# Altium schematic sheet Ttester.SchDoc
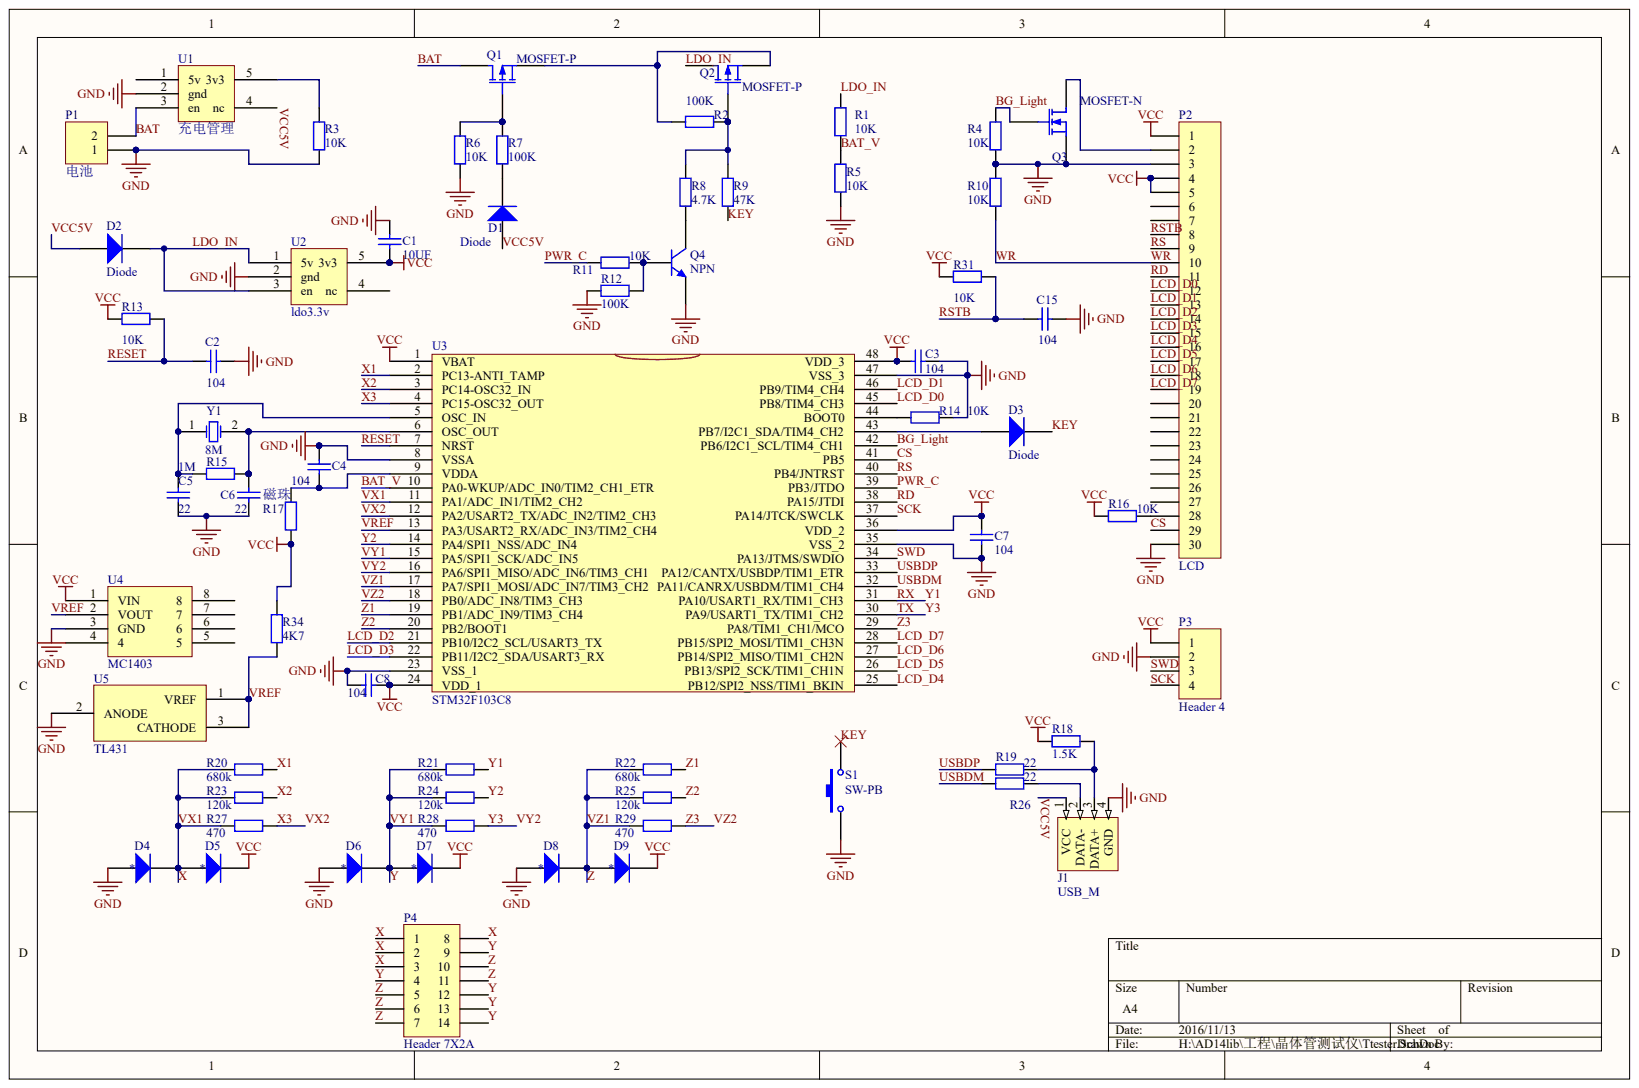
[diagram p0: Ttester.SchDoc]

## Parts
C1  value 10UF  (hidden comment: Cap)
C2  value 104  (hidden comment: Cap)
C3  value 104  (hidden comment: Cap)
C4  value 104  (hidden comment: Cap)
C5  value 22  (hidden comment: Cap)
C6  value 22  (hidden comment: Cap)
C7  value 104  (hidden comment: Cap)
C8  value 104  (hidden comment: Cap)
C15  value 104  (hidden comment: Cap)
D1  Diode
D2  Diode
D3  Diode
D4  *
D5  *
D6  *
D7  *
D8  *
D9  *
J1  USB_M
P1  电池
P2  LCD
P3  Header 4
P4  Header 7X2A
Q1  MOSFET-P
Q2  MOSFET-P
Q3  MOSFET-N
Q4  NPN
R1  value 10K  (hidden comment: Res2)
R2  value 100K  (hidden comment: Res2)
R3  value 10K  (hidden comment: Res2)
R4  value 10K  (hidden comment: Res2)
R5  value 10K  (hidden comment: Res2)
R6  value 10K  (hidden comment: Res2)
R7  value 100K  (hidden comment: Res2)
R8  value 4.7K  (hidden comment: Res2)
R9  value 47K  (hidden comment: Res2)
R10  value 10K  (hidden comment: Res2)
R11  value 10K  (hidden comment: Res2)
R12  value 100K  (hidden comment: Res2)
R13  value 10K  (hidden comment: Res2)
R14  value 10K  (hidden comment: Res2)
R15  value 1M  (hidden comment: Res2)
R16  value 10K  (hidden comment: Res2)
R17  value 磁珠  (hidden comment: 0)
R18  value 1.5K  (hidden comment: Res2)
R19  value 22  (hidden comment: Res2)
R20  value 680k  (hidden comment: Res2)
R21  value 680k  (hidden comment: Res2)
R22  value 680k  (hidden comment: Res2)
R23  value 120k  (hidden comment: Res2)
R24  value 120k  (hidden comment: Res2)
R25  value 120k  (hidden comment: Res2)
R26  value 22  (hidden comment: Res2)
R27  value 470  (hidden comment: Res2)
R28  value 470  (hidden comment: Res2)
R29  value 470  (hidden comment: Res2)
R31  value 10K  (hidden comment: Res2)
R34  value 4K7  (hidden comment: Res2)
S1  SW-PB
U1  充电管理
U2  ldo3.3v
U3  STM32F103C8
U4  MC1403
U5  TL431
Y1  8M

## Nets
GND: C1.2 C2.2 C3.2 C4.2 C5.1 C6.1 C7.1 C8.1 C15.2 D4.1 D6.1 D8.1 J1.4(GND) P1.1 P2.3 P2.30 P3.2 Q3.3 Q4.3 R3.1 R4.2 R5.2 R6.2 R10.1 R12.1 R14.1 S1.1 U1.2(gnd) U2.2(gnd) U3.8(VSSA) U3.23(VSS_1) U3.35(VSS_2) U3.47(VSS_3) U4.3(GND) U5.2
VCC: C1.1 C3.1 C7.2 C8.2 D5.2 D7.2 D9.2 P2.1 P2.4 P2.5 P3.1 R13.1 R16.2 R17.1 R18.2 R31.1 R34.2 U2.5(3v3) U3.1(VBAT) U3.24(VDD_1) U3.36(VDD_2) U3.48(VDD_3) U4.1(VIN)
BAT: P1.2 Q1.2 U1.3(en)
BAT_V: R1.2 R5.1 U3.10(PA0-WKUP/ADC_IN0/TIM2_CH1_ETR)
BG_LIGHT: Q3.1 R4.1 U3.42(PB6/I2C1_SCL/TIM4_CH1)
CS: P2.29 U3.41(PB5)
KEY: D3.2 R9.2 S1.2
LCD_D0: P2.12 U3.45(PB8/TIM4_CH3)
LCD_D1: P2.13 U3.46(PB9/TIM4_CH4)
LCD_D2: P2.14 U3.21(PB10/I2C2_SCL/USART3_TX)
LCD_D3: P2.15 U3.22(PB11/I2C2_SDA/USART3_RX)
LCD_D4: P2.16 U3.25(PB12/SPI2_NSS/TIM1_BKIN)
LCD_D5: P2.17 U3.26(PB13/SPI2_SCK/TIM1_CH1N)
LCD_D6: P2.18 U3.27(PB14/SPI2_MISO/TIM1_CH2N)
LCD_D7: P2.19 U3.28(PB15/SPI2_MOSI/TIM1_CH3N)
LDO_IN: D2.2 Q2.2 R1.1 U2.1(5v) U2.3(en)
PWR_C: R11.1 U3.39(PB3/JTDO)
RD: P2.11 U3.38(PA15/JTDI)
RESET: C2.1 R13.2 U3.7(NRST)
RS: P2.9 U3.40(PB4/JNTRST)
RSTB: C15.1 P2.8 R31.2
RX: R21.2 U3.31(PA10/USART1_RX/TIM1_CH3)
SCK: P3.4 U3.37(PA14/JTCK/SWCLK)
SWD: P3.3 U3.34(PA13/JTMS/SWDIO)
TX: R28.2 U3.16(PA6/SPI1_MISO/ADC_IN6/TIM3_CH1) U3.30(PA9/USART1_TX/TIM1_CH2)
USBDM: R26.1 U3.32(PA11/CANRX/USBDM/TIM1_CH4)
USBDP: R19.1 U3.33(PA12/CANTX/USBDP/TIM1_ETR)
VCC5V: D1.1 D2.1 J1.1(VCC) U1.4(nc)
VREF: R34.1 U3.13(PA3/USART2_RX/ADC_IN3/TIM2_CH4) U4.2(VOUT) U5.1 U5.3
VX1: D4.2 D5.1 P4.1 P4.2 P4.3 P4.8 R20.1 R23.1 R27.1 U3.11(PA1/ADC_IN1/TIM2_CH2)
VX2: R27.2 U3.4(PC15-OSC32_OUT) U3.12(PA2/USART2_TX/ADC_IN2/TIM2_CH3)
VY1: D6.2 D7.1 P4.4 P4.9 P4.12 P4.13 P4.14 R21.1 R24.1 R28.1 U3.15(PA5/SPI1_SCK/ADC_IN5)
VZ1: D8.2 D9.1 P4.5 P4.6 P4.7 P4.10 P4.11 R22.1 R25.1 R29.1 U3.17(PA7/SPI1_MOSI/ADC_IN7/TIM3_CH2)
VZ2: R29.2 U3.18(PB0/ADC_IN8/TIM3_CH3) U3.29(PA8/TIM1_CH1/MCO)
WR: P2.10 R10.2
X1: R20.2 U3.2(PC13-ANTI_TAMP)
X2: R23.2 U3.3(PC14-OSC32_IN)
Y2: R24.2 U3.14(PA4/SPI1_NSS/ADC_IN4)
Z1: R22.2 U3.19(PB1/ADC_IN9/TIM3_CH4)
Z2: R25.2 U3.20(PB2/BOOT1)
NetC4_1: C4.1 R17.2 U3.9(VDDA)
NetC5_2: C5.2 R15.1 U3.5(OSC_IN) Y1.1
NetC6_2: C6.2 R15.2 U3.6(OSC_OUT) Y1.2
NetD1_2: D1.2 R7.2
NetD3_1: D3.1 U3.43(PB7/I2C1_SDA/TIM4_CH2)
NetJ1_2: J1.2(DATA-) R26.2
NetJ1_3: J1.3(DATA+) R18.1 R19.2
NetP2_2: P2.2 Q3.2
NetP2_28: P2.28 R16.1
NetQ1_1: Q1.1 R6.1 R7.1
NetQ1_3: Q1.3 Q2.3 R2.2
NetQ2_1: Q2.1 R2.1 R8.1 R9.1
NetQ4_1: Q4.1 R8.2
NetQ4_2: Q4.2 R11.2 R12.2
NetR3_2: R3.2 U1.5(3v3)
NetR14_2: R14.2 U3.44(BOOT0)

Not connected: P2 6 7 20 21 22 23 24 25 26 27; U1 1(5v); U2 4(nc); U4 4 5 6 7 8

## Library data of the parts
R14 = (no symbol name): Value: 10K; Published: 8-Jun-2000; LatestRevisionDate: 17-Jul-2002; LatestRevisionNote: Re-released for DXP Platform.; PackageReference: AXIAL-0.4; PackageDescription: Axial Device, Thru-Hole; 2 Leads; 0.4 in Pin Spacing; Publisher: Altium Limited
C1-C8 C15 D1-D9 J1 P1-P4 Q1-Q4 R1-R13 R15-R29 R31 R34 S1 U1-U5 Y1 = (no symbol name)
  C1-C8 C15: Published: 8-Jun-2000; LatestRevisionDate: 17-Jul-2002; LatestRevisionNote: Re-released for DXP Platform.; PackageReference: RAD-0.3; PackageDescription: Radial Cap, Thru-Hole; 2 Leads; 0.3 in Pin Spacing
  D1-D3: Published: 8-Jun-2000; LatestRevisionDate: 17-Jul-2002; LatestRevisionNote: Re-released for DXP Platform.; PackageVersion: Sep-1996; PackageReference: SMC; PackageDescription: DO-214-AB/SMC; 2 C-Bend Leads; Body 7.9 x 5.9 mm, inc. leads (LxW); Code_JEDEC: DO-214-AB
  D4-D9: Published: 8-Jun-2000; LatestRevisionDate: 17-Jul-2002; LatestRevisionNote: Re-released for DXP Platform.; DatasheetVersion: 1989; PackageVersion: 1989; PackageReference: DO-35A; PackageDescription: Diode, Axial; Body 4.6 x 1.9 mm (LxDia. max), Lead Dia. 0.53 mm (max); Code_JEDEC: DO-204-AH; ComponentLink1Description: Manufacturer Link; ComponentLink1URL: http://www.national.com/
  P1-P4: LatestRevisionDate: 17-Jul-2002; LatestRevisionNote: Re-released for DXP Platform.
  Q1-Q3: Published: 8-Jun-2000; LatestRevisionDate: 17-Jul-2002; LatestRevisionNote: Re-released for DXP Platform.; PackageVersion: 1995/1996; PackageReference: E3; PackageDescription: TO, Flat Index; 3 In-Line, Axial Leads; Body Dia. 4.6mm; Lead Dia. 0.5 mm (max)
  Q4: Published: 8-Jun-2000; LatestRevisionDate: 17-Jul-2002; LatestRevisionNote: Re-released for DXP Platform.; PackageReference: TO-226-AA; PackageDescription: TO, Flat Index; 3 In-Line, Axial Leads; Body Dia. 4.8mm; Leads 0.55 x 0.51 mm (max); Code_JEDEC: TO-226-AA
  R1-R13 R15-R29 R31 R34: Published: 8-Jun-2000; LatestRevisionDate: 17-Jul-2002; LatestRevisionNote: Re-released for DXP Platform.; PackageReference: AXIAL-0.4; PackageDescription: Axial Device, Thru-Hole; 2 Leads; 0.4 in Pin Spacing
  S1: Published: 24-Mar-1999; LatestRevisionDate: 17-Jul-2002; LatestRevisionNote: Re-released for DXP Platform.; PackageReference: SPST-2; PackageDescription: Switch; 2 Leads
  C1-C8 C15 D1-D9 P1-P4 Q1-Q4 R1-R13 R15-R29 R31 R34 S1: Publisher: Altium Limited
